# Altium schematic sheet power.SchDoc(U_power)
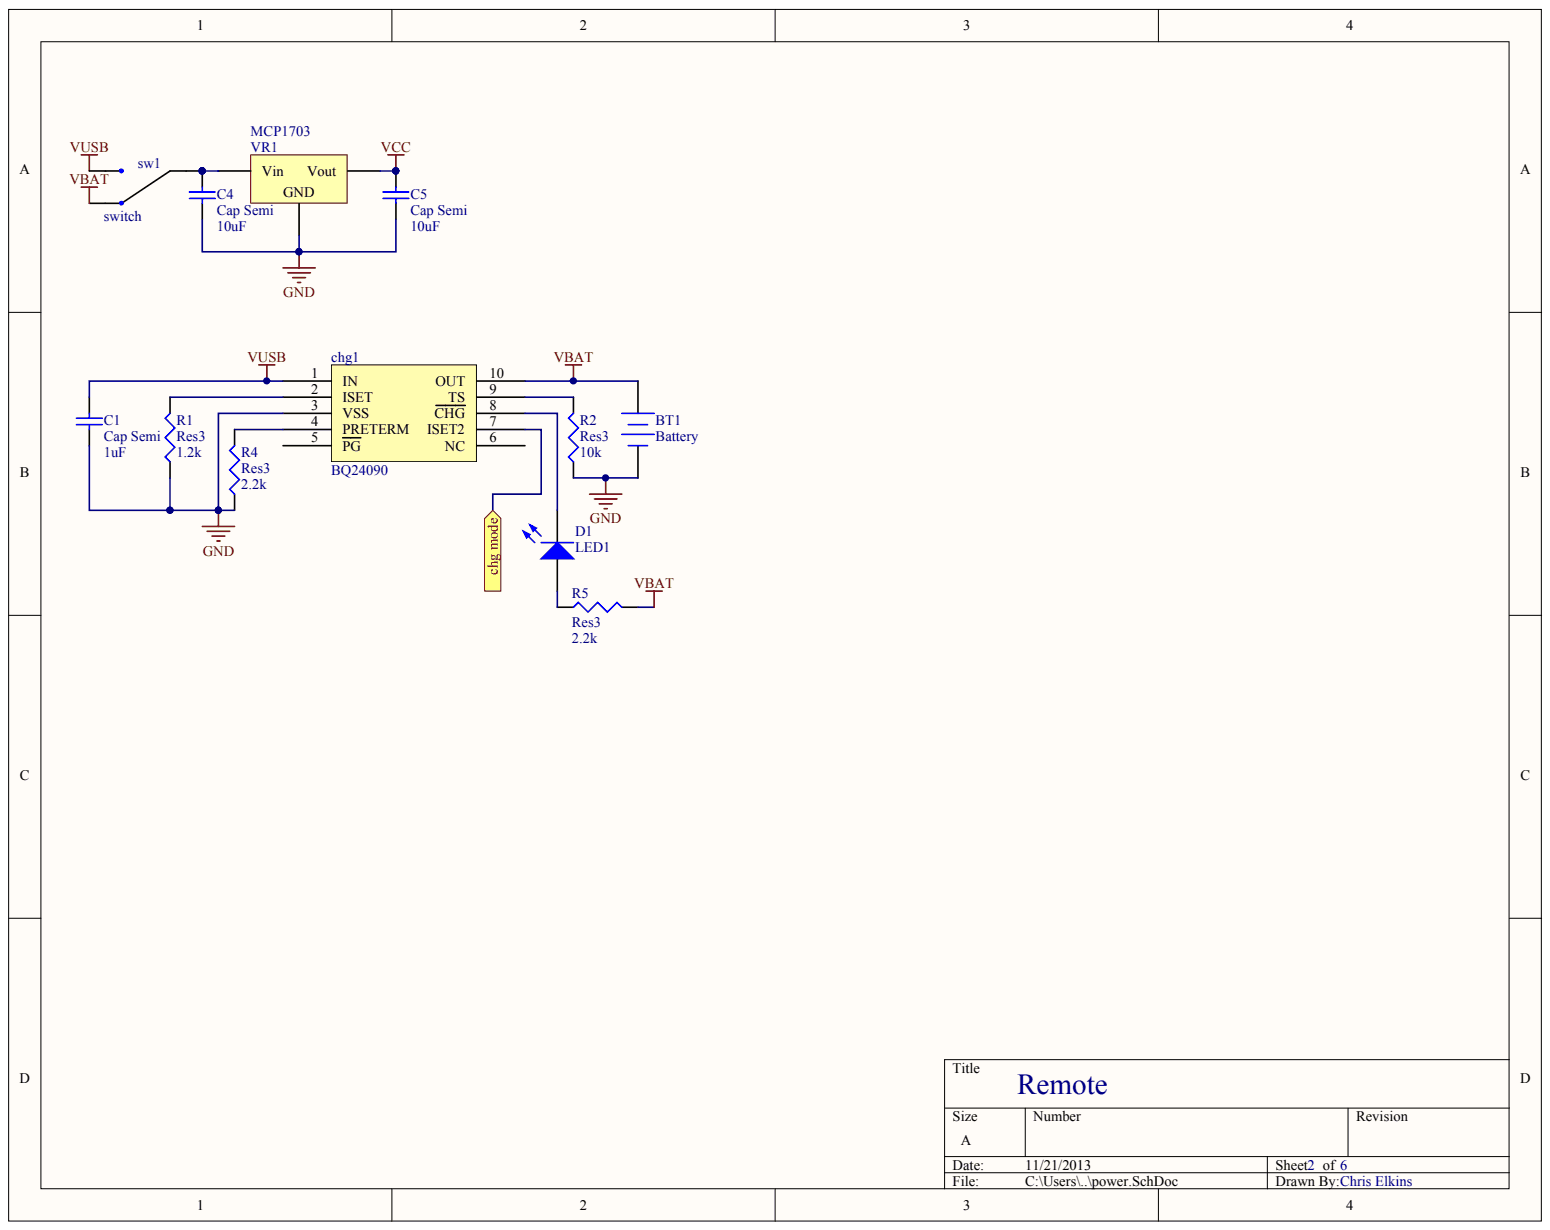
[diagram p0: power.SchDoc]

## Parts
BT1  Battery
C1  Cap Semi  value 1uF
C4  Cap Semi  value 10uF
C5  Cap Semi  value 10uF
chg1  BQ24090
D1  LED1
R1  Res3  value 1.2k
R2  Res3  value 10k
R4  Res3  value 2.2k
R5  Res3  value 2.2k
sw1  switch
VR1  MCP1703

## Ports
CHG MODE

## Nets
GND: BT1.2 C1.1 C4.1 C5.1 chg1.3(VSS) R1.1 R2.1 R4.1 VR1.2
VBAT: BT1.1 chg1.10(OUT) R5.2 sw1.1
VCC: C5.2 VR1.3
VUSB: C1.2 chg1.1(IN) sw1.3
NetC4_2: C4.2 sw1.2 VR1.1
Netchg1_2: chg1.2(ISET) R1.2
Netchg1_4: chg1.4(PRETERM) R4.2
Netchg1_7: chg1.7(ISET2) ; port CHG MODE
Netchg1_8: chg1.8(CHG) D1.2
Netchg1_9: chg1.9(TS) R2.2
NetD1_1: D1.1 R5.1

Not connected: chg1 5(PG) 6(NC)

## Library data of the parts
BT1 C1 C4 C5 chg1 D1 R1 R2 R4 R5 sw1 VR1 = (no symbol name)
  BT1: Published: 24-Mar-1999; LatestRevisionDate: 17-Jul-2002; LatestRevisionNote: Re-released for DXP Platform.; PackageReference: BAT-2
  C1 C4 C5: Published: 8-Jun-2000; LatestRevisionDate: 29-May-2009; LatestRevisionNote: IPC-7351 Footprint Added.; PackageReference: C1206; PackageDescription: Chip Capacitor
  D1: Published: 8-Jun-2000; LatestRevisionDate: 08-Jul-2005; LatestRevisionNote: Mech Layer 1 removed.; PackageReference: LED-1
  R1 R2 R4 R5: Published: 8-Jun-2000; LatestRevisionDate: 29-May-2009; LatestRevisionNote: IPC-7351 Footprint Added.; PackageReference: J1-0603; PackageDescription: Chip Resistor
  VR1: Published: 24-Mar-1999; LatestRevisionDate: 29-May-2009; LatestRevisionNote: IPC-7351 Footprint Added.; PackageReference: D2PAK; PackageDescription: 3-Pin Transistor Outline Package 2.54 mm Pitch, 15.1 mm Lead Span
  BT1 C1 C4 C5 D1 R1 R2 R4 R5 VR1: Publisher: Altium Limited
